# Altium schematic sheet DDR3.SchDoc
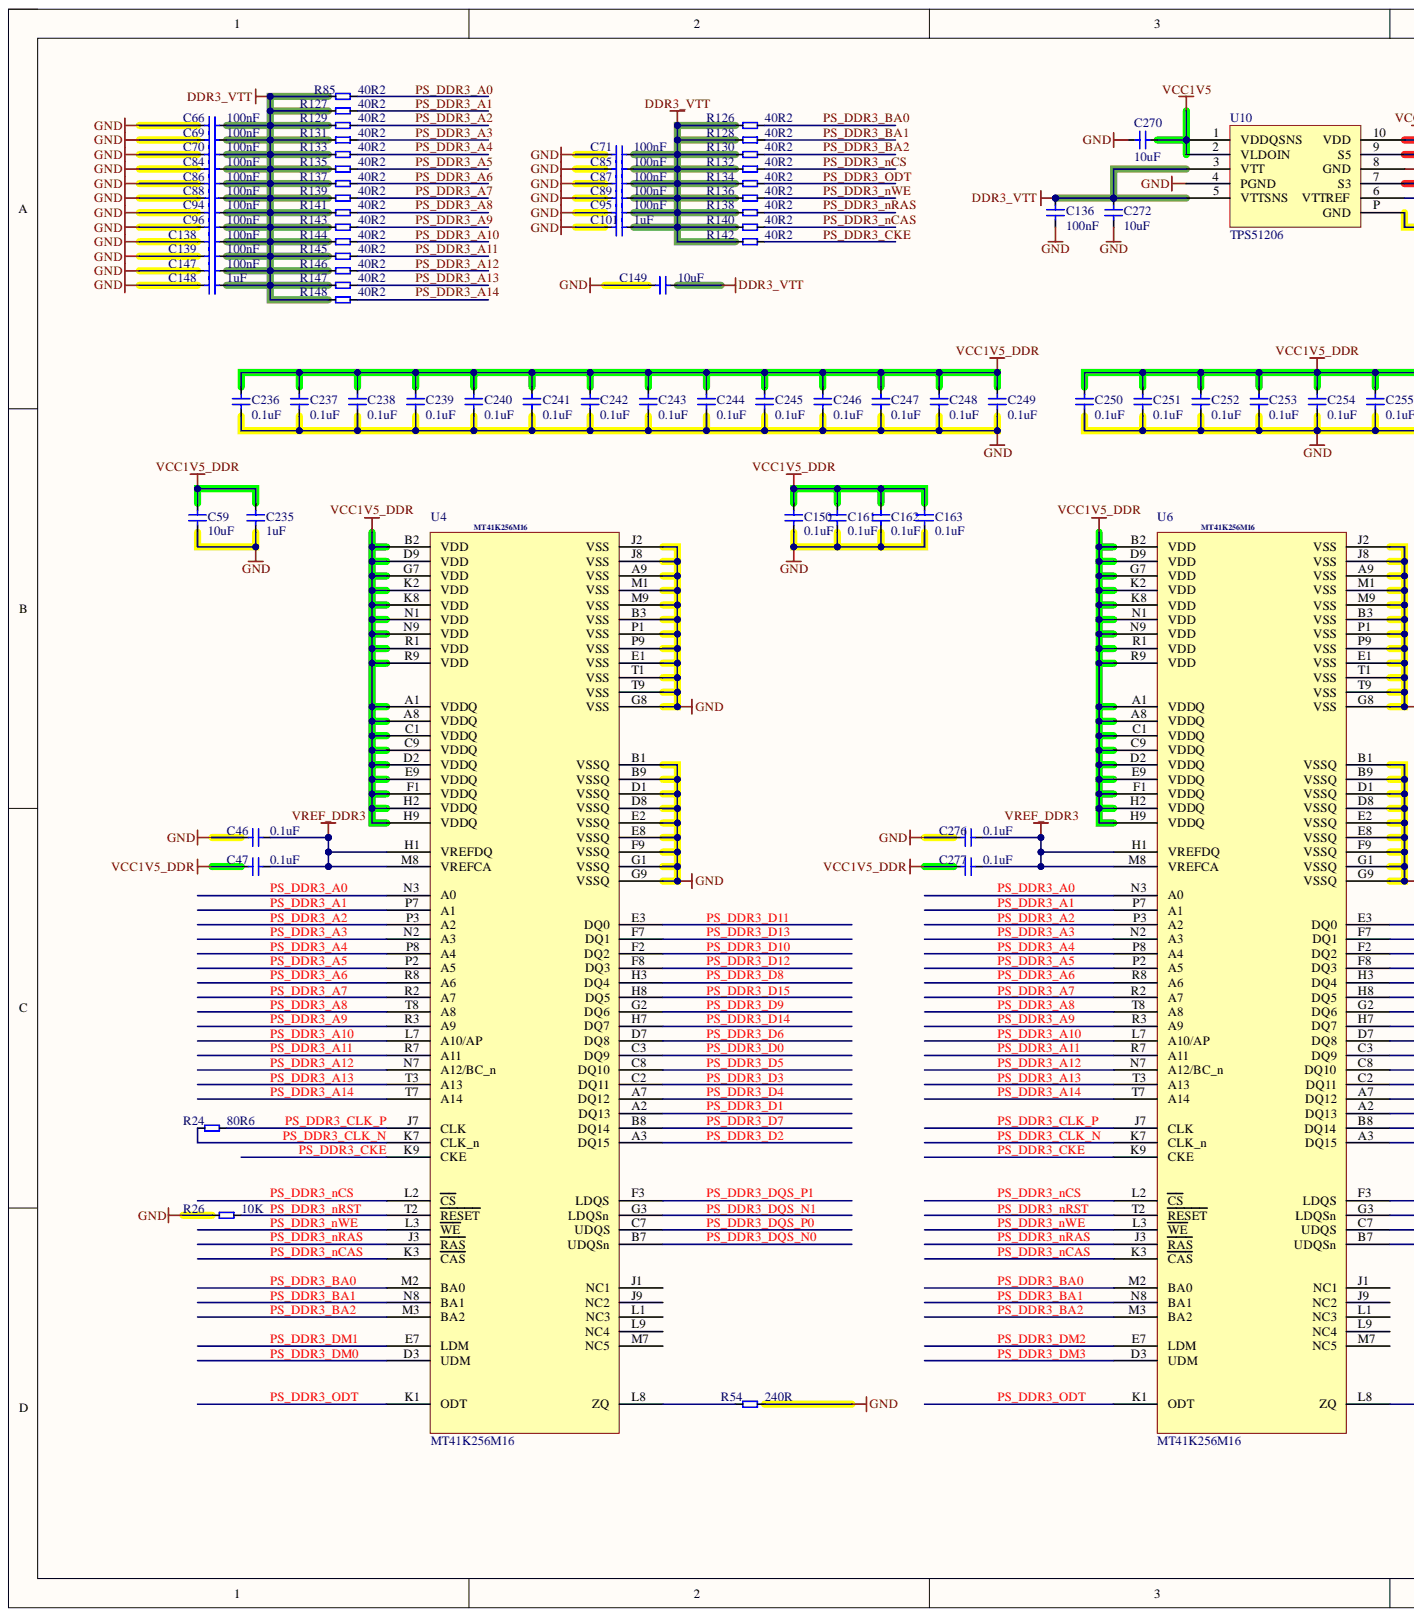
[diagram p0: DDR3.SchDoc, left]
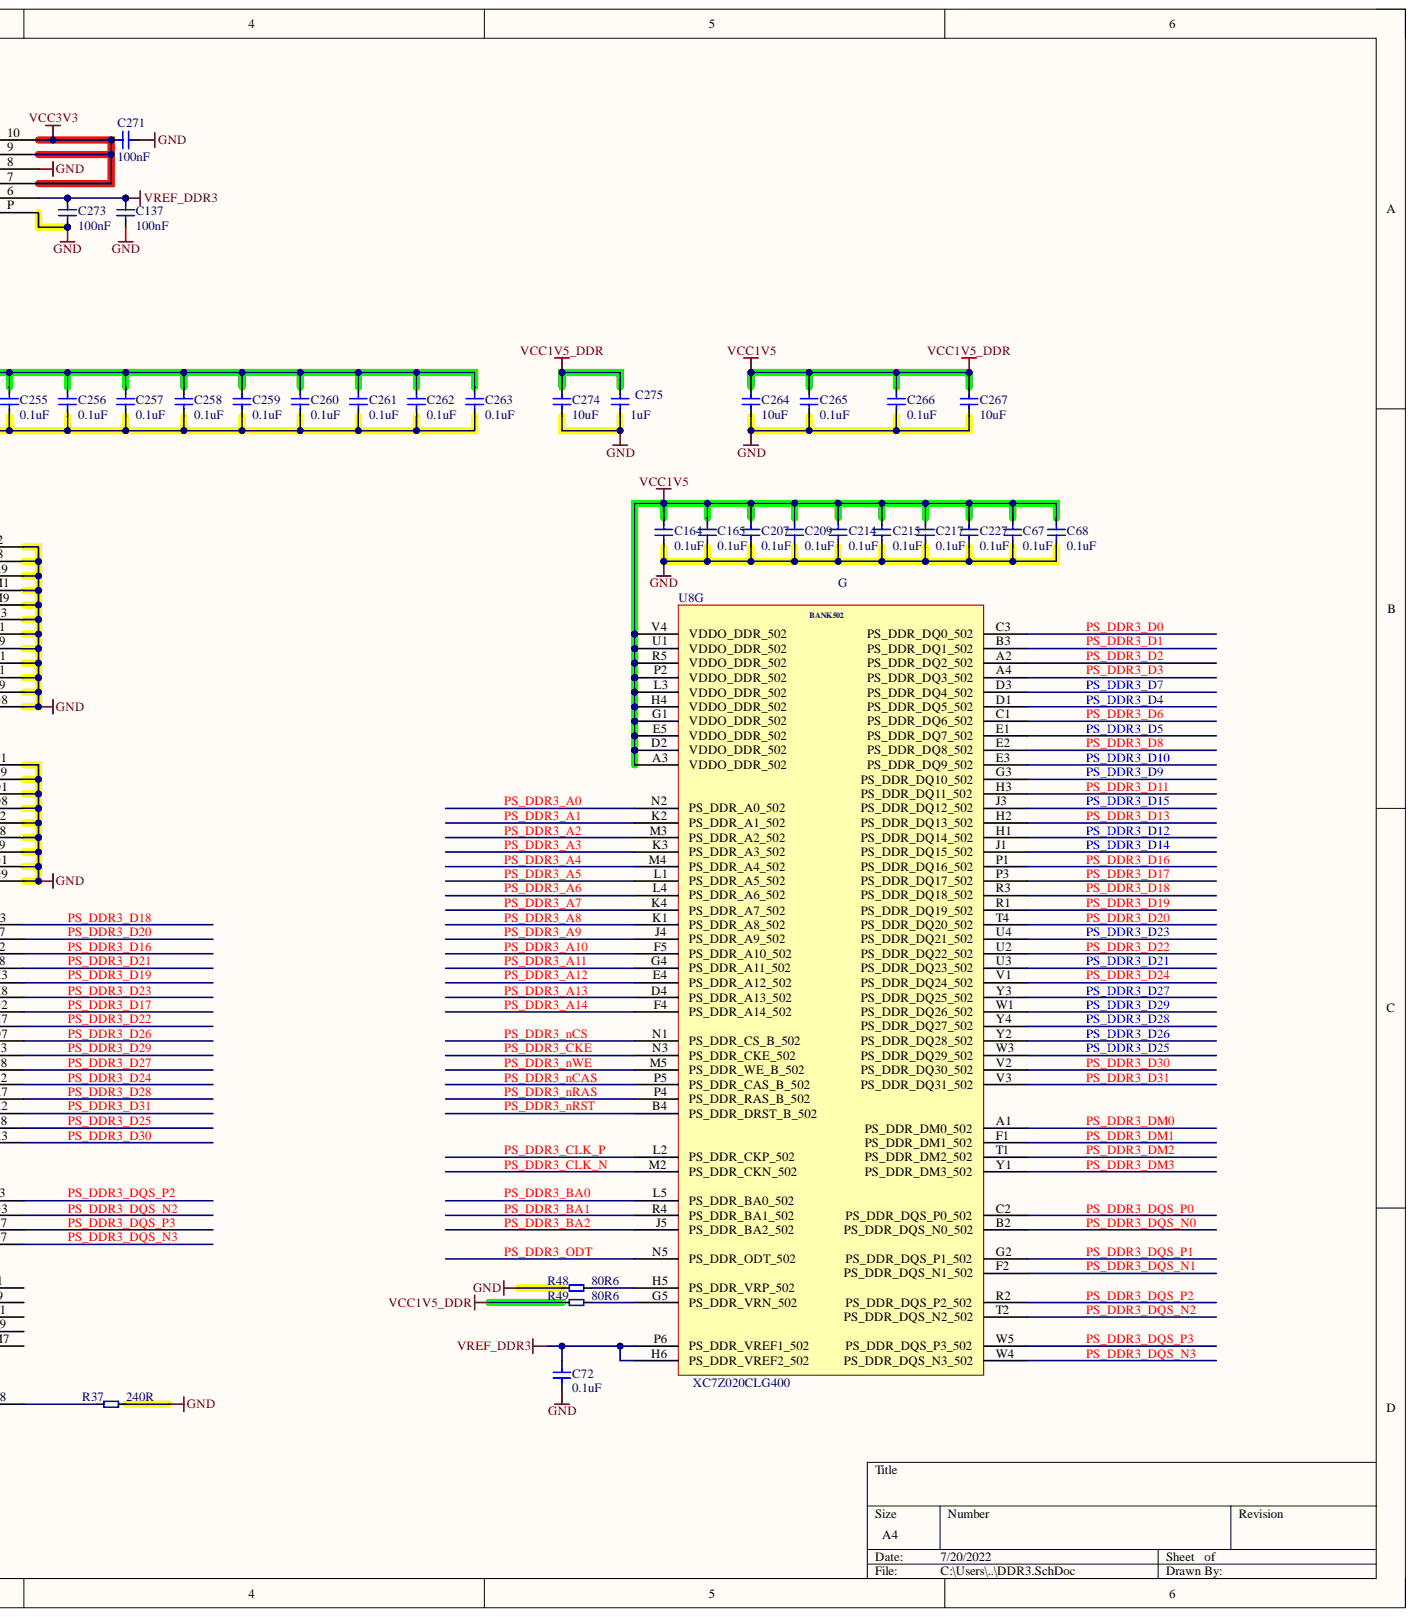
[diagram p1: DDR3.SchDoc, right]

## Parts
C46  0.1uF  [C0201]
C47  0.1uF  [C0201]
C59  10uF  [C0603]
C66  100nF  [C0201]
C67  0.1uF  [C0201]
C68  0.1uF  [C0201]
C69  100nF  [C0201]
C70  100nF  [C0201]
C71  100nF  [C0201]
C72  0.1uF  [C0201]
C84  100nF  [C0201]
C85  100nF  [C0201]
C86  100nF  [C0201]
C87  100nF  [C0201]
C88  100nF  [C0201]
C89  100nF  [C0201]
C94  100nF  [C0201]
C95  100nF  [C0201]
C96  100nF  [C0201]
C101  1uF  [C0402]
C136  100nF  [C0201]
C137  100nF  [C0201]
C138  100nF  [C0201]
C139  100nF  [C0201]
C147  100nF  [C0201]
C148  1uF  [C0402]
C149  10uF  [C0603]
C150  0.1uF  [C0201]
C161  0.1uF  [C0201]
C162  0.1uF  [C0201]
C163  0.1uF  [C0201]
C164  0.1uF  [C0201]
C165  0.1uF  [C0201]
C207  0.1uF  [C0201]
C209  0.1uF  [C0201]
C214  0.1uF  [C0201]
C215  0.1uF  [C0201]
C217  0.1uF  [C0201]
C227  0.1uF  [C0201]
C235  1uF  [C0402]
C236  0.1uF  [C0201]
C237  0.1uF  [C0201]
C238  0.1uF  [C0201]
C239  0.1uF  [C0201]
C240  0.1uF  [C0201]
C241  0.1uF  [C0201]
C242  0.1uF  [C0201]
C243  0.1uF  [C0201]
C244  0.1uF  [C0201]
C245  0.1uF  [C0201]
C246  0.1uF  [C0201]
C247  0.1uF  [C0201]
C248  0.1uF  [C0201]
C249  0.1uF  [C0201]
C250  0.1uF  [C0201]
C251  0.1uF  [C0201]
C252  0.1uF  [C0201]
C253  0.1uF  [C0201]
C254  0.1uF  [C0201]
C255  0.1uF  [C0201]
C256  0.1uF  [C0201]
C257  0.1uF  [C0201]
C258  0.1uF  [C0201]
C259  0.1uF  [C0201]
C260  0.1uF  [C0201]
C261  0.1uF  [C0201]
C262  0.1uF  [C0201]
C263  0.1uF  [C0201]
C264  10uF  [C0603]
C265  0.1uF  [C0201]
C266  0.1uF  [C0201]
C267  10uF  [C0603]
C270  10uF  [C0603]
C271  100nF  [C0201]
C272  10uF  [C0603]
C273  100nF  [C0201]
C274  10uF  [C0603]
C275  1uF  [C0402]
C276  0.1uF  [C0201]
C277  0.1uF  [C0201]
R24  80R6  [R0201]
R26  10K  [R0201]
R37  240R  [R0201]
R48  80R6  [R0201]
R49  80R6  [R0201]
R54  240R  [R0201]
R85  40R2  [R0201]
R126  40R2  [R0201]
R127  40R2  [R0201]
R128  40R2  [R0201]
R129  40R2  [R0201]
R130  40R2  [R0201]
R131  40R2  [R0201]
R132  40R2  [R0201]
R133  40R2  [R0201]
R134  40R2  [R0201]
R135  40R2  [R0201]
R136  40R2  [R0201]
R137  40R2  [R0201]
R138  40R2  [R0201]
R139  40R2  [R0201]
R140  40R2  [R0201]
R141  40R2  [R0201]
R142  40R2  [R0201]
R143  40R2  [R0201]
R144  40R2  [R0201]
R145  40R2  [R0201]
R146  40R2  [R0201]
R147  40R2  [R0201]
R148  40R2  [R0201]
U4  MT41K256M16  [BGA96C]
U6  MT41K256M16  [BGA96C]
U8  XC7Z020CLG400  [MY_CLG400]  (part G)
U10  TPS51206  [QFN-10_2x2x04P]

## Nets
DDR3_VTT: C66.2 C69.2 C70.2 C71.2 C84.2 C85.2 C86.2 C87.2 C88.2 C89.2 C94.2 C95.2 C96.2 C101.2 C136.2 C138.2 C139.2 C147.2 C148.2 C149.2 C272.2 R85.1 R126.1 R127.1 R128.1 R129.1 R130.1 R131.1 R132.1 R133.1 R134.1 R135.1 R136.1 R137.1 R138.1 R139.1 R140.1 R141.1 R142.1 R143.1 R144.1 R145.1 R146.1 R147.1 R148.1 U10.3(VTT) U10.5(VTTSNS)
GND: C46.2 C59.1 C66.1 C67.1 C68.1 C69.1 C70.1 C71.1 C72.2 C84.1 C85.1 C86.1 C87.1 C88.1 C89.1 C94.1 C95.1 C96.1 C101.1 C136.1 C137.1 C138.1 C139.1 C147.1 C148.1 C149.1 C150.1 C161.1 C162.1 C163.1 C164.1 C165.1 C207.1 C209.1 C214.1 C215.1 C217.1 C227.1 C235.1 C236.1 C237.1 C238.1 C239.1 C240.1 C241.1 C242.1 C243.1 C244.1 C245.1 C246.1 C247.1 C248.1 C249.1 C250.1 C251.1 C252.1 C253.1 C254.1 C255.1 C256.1 C257.1 C258.1 C259.1 C260.1 C261.1 C262.1 C263.1 C264.1 C265.1 C266.1 C267.1 C270.1 C271.1 C272.1 C273.1 C274.1 C275.1 C276.2 R26.1 R37.2 R48.1 R54.2 U4.A9(VSS) U4.B1(VSSQ) U4.B3(VSS) U4.B9(VSSQ) U4.D1(VSSQ) U4.D8(VSSQ) U4.E1(VSS) U4.E2(VSSQ) U4.E8(VSSQ) U4.F9(VSSQ) U4.G1(VSSQ) U4.G8(VSS) U4.G9(VSSQ) U4.J2(VSS) U4.J8(VSS) U4.M1(VSS) U4.M9(VSS) U4.P1(VSS) U4.P9(VSS) U4.T1(VSS) U4.T9(VSS) U6.A9(VSS) U6.B1(VSSQ) U6.B3(VSS) U6.B9(VSSQ) U6.D1(VSSQ) U6.D8(VSSQ) U6.E1(VSS) U6.E2(VSSQ) U6.E8(VSSQ) U6.F9(VSSQ) U6.G1(VSSQ) U6.G8(VSS) U6.G9(VSSQ) U6.J2(VSS) U6.J8(VSS) U6.M1(VSS) U6.M9(VSS) U6.P1(VSS) U6.P9(VSS) U6.T1(VSS) U6.T9(VSS) U10.4(PGND) U10.8(GND) U10.P(GND)
VCC1V5: C47.2 C59.2 C67.2 C68.2 C150.2 C161.2 C162.2 C163.2 C164.2 C165.2 C207.2 C209.2 C214.2 C215.2 C217.2 C227.2 C235.2 C236.2 C237.2 C238.2 C239.2 C240.2 C241.2 C242.2 C243.2 C244.2 C245.2 C246.2 C247.2 C248.2 C249.2 C250.2 C251.2 C252.2 C253.2 C254.2 C255.2 C256.2 C257.2 C258.2 C259.2 C260.2 C261.2 C262.2 C263.2 C264.2 C265.2 C266.2 C267.2 C270.2 C274.2 C275.2 C277.2 R49.1 U4.A1(VDDQ) U4.A8(VDDQ) U4.B2(VDD) U4.C1(VDDQ) U4.C9(VDDQ) U4.D2(VDDQ) U4.D9(VDD) U4.E9(VDDQ) U4.F1(VDDQ) U4.G7(VDD) U4.H2(VDDQ) U4.H9(VDDQ) U4.K2(VDD) U4.K8(VDD) U4.N1(VDD) U4.N9(VDD) U4.R1(VDD) U4.R9(VDD) U6.A1(VDDQ) U6.A8(VDDQ) U6.B2(VDD) U6.C1(VDDQ) U6.C9(VDDQ) U6.D2(VDDQ) U6.D9(VDD) U6.E9(VDDQ) U6.F1(VDDQ) U6.G7(VDD) U6.H2(VDDQ) U6.H9(VDDQ) U6.K2(VDD) U6.K8(VDD) U6.N1(VDD) U6.N9(VDD) U6.R1(VDD) U6.R9(VDD) U8.A3(VDDO_DDR_502) U8.D2(VDDO_DDR_502) U8.E5(VDDO_DDR_502) U8.G1(VDDO_DDR_502) U8.H4(VDDO_DDR_502) U8.L3(VDDO_DDR_502) U8.P2(VDDO_DDR_502) U8.R5(VDDO_DDR_502) U8.U1(VDDO_DDR_502) U8.V4(VDDO_DDR_502) U10.1(VDDQSNS) U10.2(VLDOIN)
VCC3V3: C271.2 U10.7(S3) U10.9(S5) U10.10(VDD)
VREF_DDR3: C46.1 C47.1 C72.1 C137.2 C273.2 C276.1 C277.1 U4.H1(VREFDQ) U4.M8(VREFCA) U6.H1(VREFDQ) U6.M8(VREFCA) U8.H6(PS_DDR_VREF2_502) U8.P6(PS_DDR_VREF1_502) U10.6(VTTREF)
PS_DDR3_A0: R85.2 U4.N3(A0) U6.N3(A0) U8.N2(PS_DDR_A0_502)
PS_DDR3_A1: R127.2 U4.P7(A1) U6.P7(A1) U8.K2(PS_DDR_A1_502)
PS_DDR3_A2: R129.2 U4.P3(A2) U6.P3(A2) U8.M3(PS_DDR_A2_502)
PS_DDR3_A3: R131.2 U4.N2(A3) U6.N2(A3) U8.K3(PS_DDR_A3_502)
PS_DDR3_A4: R133.2 U4.P8(A4) U6.P8(A4) U8.M4(PS_DDR_A4_502)
PS_DDR3_A5: R135.2 U4.P2(A5) U6.P2(A5) U8.L1(PS_DDR_A5_502)
PS_DDR3_A6: R137.2 U4.R8(A6) U6.R8(A6) U8.L4(PS_DDR_A6_502)
PS_DDR3_A7: R139.2 U4.R2(A7) U6.R2(A7) U8.K4(PS_DDR_A7_502)
PS_DDR3_A8: R141.2 U4.T8(A8) U6.T8(A8) U8.K1(PS_DDR_A8_502)
PS_DDR3_A9: R143.2 U4.R3(A9) U6.R3(A9) U8.J4(PS_DDR_A9_502)
PS_DDR3_A10: R144.2 U4.L7(A10/AP) U6.L7(A10/AP) U8.F5(PS_DDR_A10_502)
PS_DDR3_A11: R145.2 U4.R7(A11) U6.R7(A11) U8.G4(PS_DDR_A11_502)
PS_DDR3_A12: R146.2 U4.N7(A12/BC_n) U6.N7(A12/BC_n) U8.E4(PS_DDR_A12_502)
PS_DDR3_A13: R147.2 U4.T3(A13) U6.T3(A13) U8.D4(PS_DDR_A13_502)
PS_DDR3_A14: R148.2 U4.T7(A14) U6.T7(A14) U8.F4(PS_DDR_A14_502)
PS_DDR3_BA0: R126.2 U4.M2(BA0) U6.M2(BA0) U8.L5(PS_DDR_BA0_502)
PS_DDR3_BA1: R128.2 U4.N8(BA1) U6.N8(BA1) U8.R4(PS_DDR_BA1_502)
PS_DDR3_BA2: R130.2 U4.M3(BA2) U6.M3(BA2) U8.J5(PS_DDR_BA2_502)
PS_DDR3_CKE: R142.2 U4.K9(CKE) U6.K9(CKE) U8.N3(PS_DDR_CKE_502)
PS_DDR3_CLK_N: R24.1 U4.K7(CLK_n) U6.K7(CLK_n) U8.M2(PS_DDR_CKN_502)
PS_DDR3_CLK_P: R24.2 U4.J7(CLK) U6.J7(CLK) U8.L2(PS_DDR_CKP_502)
PS_DDR3_D0: U4.C3(DQ9) U8.C3(PS_DDR_DQ0_502)
PS_DDR3_D1: U4.A2(DQ13) U8.B3(PS_DDR_DQ1_502)
PS_DDR3_D2: U4.A3(DQ15) U8.A2(PS_DDR_DQ2_502)
PS_DDR3_D3: U4.C2(DQ11) U8.A4(PS_DDR_DQ3_502)
PS_DDR3_D4: U4.A7(DQ12) U8.D1(PS_DDR_DQ5_502)
PS_DDR3_D5: U4.C8(DQ10) U8.E1(PS_DDR_DQ7_502)
PS_DDR3_D6: U4.D7(DQ8) U8.C1(PS_DDR_DQ6_502)
PS_DDR3_D7: U4.B8(DQ14) U8.D3(PS_DDR_DQ4_502)
PS_DDR3_D8: U4.H3(DQ4) U8.E2(PS_DDR_DQ8_502)
PS_DDR3_D9: U4.G2(DQ6) U8.G3(PS_DDR_DQ10_502)
PS_DDR3_D10: U4.F2(DQ2) U8.E3(PS_DDR_DQ9_502)
PS_DDR3_D11: U4.E3(DQ0) U8.H3(PS_DDR_DQ11_502)
PS_DDR3_D12: U4.F8(DQ3) U8.H1(PS_DDR_DQ14_502)
PS_DDR3_D13: U4.F7(DQ1) U8.H2(PS_DDR_DQ13_502)
PS_DDR3_D14: U4.H7(DQ7) U8.J1(PS_DDR_DQ15_502)
PS_DDR3_D15: U4.H8(DQ5) U8.J3(PS_DDR_DQ12_502)
PS_DDR3_D16: U6.F2(DQ2) U8.P1(PS_DDR_DQ16_502)
PS_DDR3_D17: U6.G2(DQ6) U8.P3(PS_DDR_DQ17_502)
PS_DDR3_D18: U6.E3(DQ0) U8.R3(PS_DDR_DQ18_502)
PS_DDR3_D19: U6.H3(DQ4) U8.R1(PS_DDR_DQ19_502)
PS_DDR3_D20: U6.F7(DQ1) U8.T4(PS_DDR_DQ20_502)
PS_DDR3_D21: U6.F8(DQ3) U8.U3(PS_DDR_DQ23_502)
PS_DDR3_D22: U6.H7(DQ7) U8.U2(PS_DDR_DQ22_502)
PS_DDR3_D23: U6.H8(DQ5) U8.U4(PS_DDR_DQ21_502)
PS_DDR3_D24: U6.C2(DQ11) U8.V1(PS_DDR_DQ24_502)
PS_DDR3_D25: U6.B8(DQ14) U8.W3(PS_DDR_DQ29_502)
PS_DDR3_D26: U6.D7(DQ8) U8.Y2(PS_DDR_DQ28_502)
PS_DDR3_D27: U6.C8(DQ10) U8.Y3(PS_DDR_DQ25_502)
PS_DDR3_D28: U6.A7(DQ12) U8.Y4(PS_DDR_DQ27_502)
PS_DDR3_D29: U6.C3(DQ9) U8.W1(PS_DDR_DQ26_502)
PS_DDR3_D30: U6.A3(DQ15) U8.V2(PS_DDR_DQ30_502)
PS_DDR3_D31: U6.A2(DQ13) U8.V3(PS_DDR_DQ31_502)
PS_DDR3_DM0: U4.D3(UDM) U8.A1(PS_DDR_DM0_502)
PS_DDR3_DM1: U4.E7(LDM) U8.F1(PS_DDR_DM1_502)
PS_DDR3_DM2: U6.E7(LDM) U8.T1(PS_DDR_DM2_502)
PS_DDR3_DM3: U6.D3(UDM) U8.Y1(PS_DDR_DM3_502)
PS_DDR3_DQS_N0: U4.B7(UDQSn) U8.B2(PS_DDR_DQS_N0_502)
PS_DDR3_DQS_N1: U4.G3(LDQSn) U8.F2(PS_DDR_DQS_N1_502)
PS_DDR3_DQS_N2: U6.G3(LDQSn) U8.T2(PS_DDR_DQS_N2_502)
PS_DDR3_DQS_N3: U6.B7(UDQSn) U8.W4(PS_DDR_DQS_N3_502)
PS_DDR3_DQS_P0: U4.C7(UDQS) U8.C2(PS_DDR_DQS_P0_502)
PS_DDR3_DQS_P1: U4.F3(LDQS) U8.G2(PS_DDR_DQS_P1_502)
PS_DDR3_DQS_P2: U6.F3(LDQS) U8.R2(PS_DDR_DQS_P2_502)
PS_DDR3_DQS_P3: U6.C7(UDQS) U8.W5(PS_DDR_DQS_P3_502)
PS_DDR3_nCAS: R140.2 U4.K3(CAS) U6.K3(CAS) U8.P5(PS_DDR_CAS_B_502)
PS_DDR3_nCS: R132.2 U4.L2(CS) U6.L2(CS) U8.N1(PS_DDR_CS_B_502)
PS_DDR3_nRAS: R138.2 U4.J3(RAS) U6.J3(RAS) U8.P4(PS_DDR_RAS_B_502)
PS_DDR3_nRST: R26.2 U4.T2(RESET) U6.T2(RESET) U8.B4(PS_DDR_DRST_B_502)
PS_DDR3_nWE: R136.2 U4.L3(WE) U6.L3(WE) U8.M5(PS_DDR_WE_B_502)
PS_DDR3_ODT: R134.2 U4.K1(ODT) U6.K1(ODT) U8.N5(PS_DDR_ODT_502)
NetR37_1: R37.1 U6.L8(ZQ)
NetR48_2: R48.2 U8.H5(PS_DDR_VRP_502)
NetR49_2: R49.2 U8.G5(PS_DDR_VRN_502)
NetR54_1: R54.1 U4.L8(ZQ)

Not connected: U4 J1(NC1) J9(NC2) L1(NC3) L9(NC4) M7(NC5); U6 J1(NC1) J9(NC2) L1(NC3) L9(NC4) M7(NC5)

## Library data of the parts
C46 C47 C59 C66-C72 C84-C89 C94-C96 C101 C136-C139 C147-C150 C161-C165 C207 C209 C214 C215 C217 C227 C235-C267 C270-C277 = C: Capacitor; Component Kind: Standard; Library Name: 电容-SCH.LIB
R24 R26 R37 R48 R49 R54 R85 R126-R148 = RES2: Component Kind: Standard; Library Name: 电阻-SCH.LIB
U4 U6 = MT41K256M16: Component Kind: Standard; Library Name: Schlib1.SchLib
U8 = XC7Z020CLG400: Component Kind: Standard; Library Name: Schlib1.SchLib
U10 = TPS51206: Component Kind: Standard; Library Name: antsdr.SCHLIB
